# Altium schematic sheet I2C Expander 3.SchDoc("I2C Expander 3")
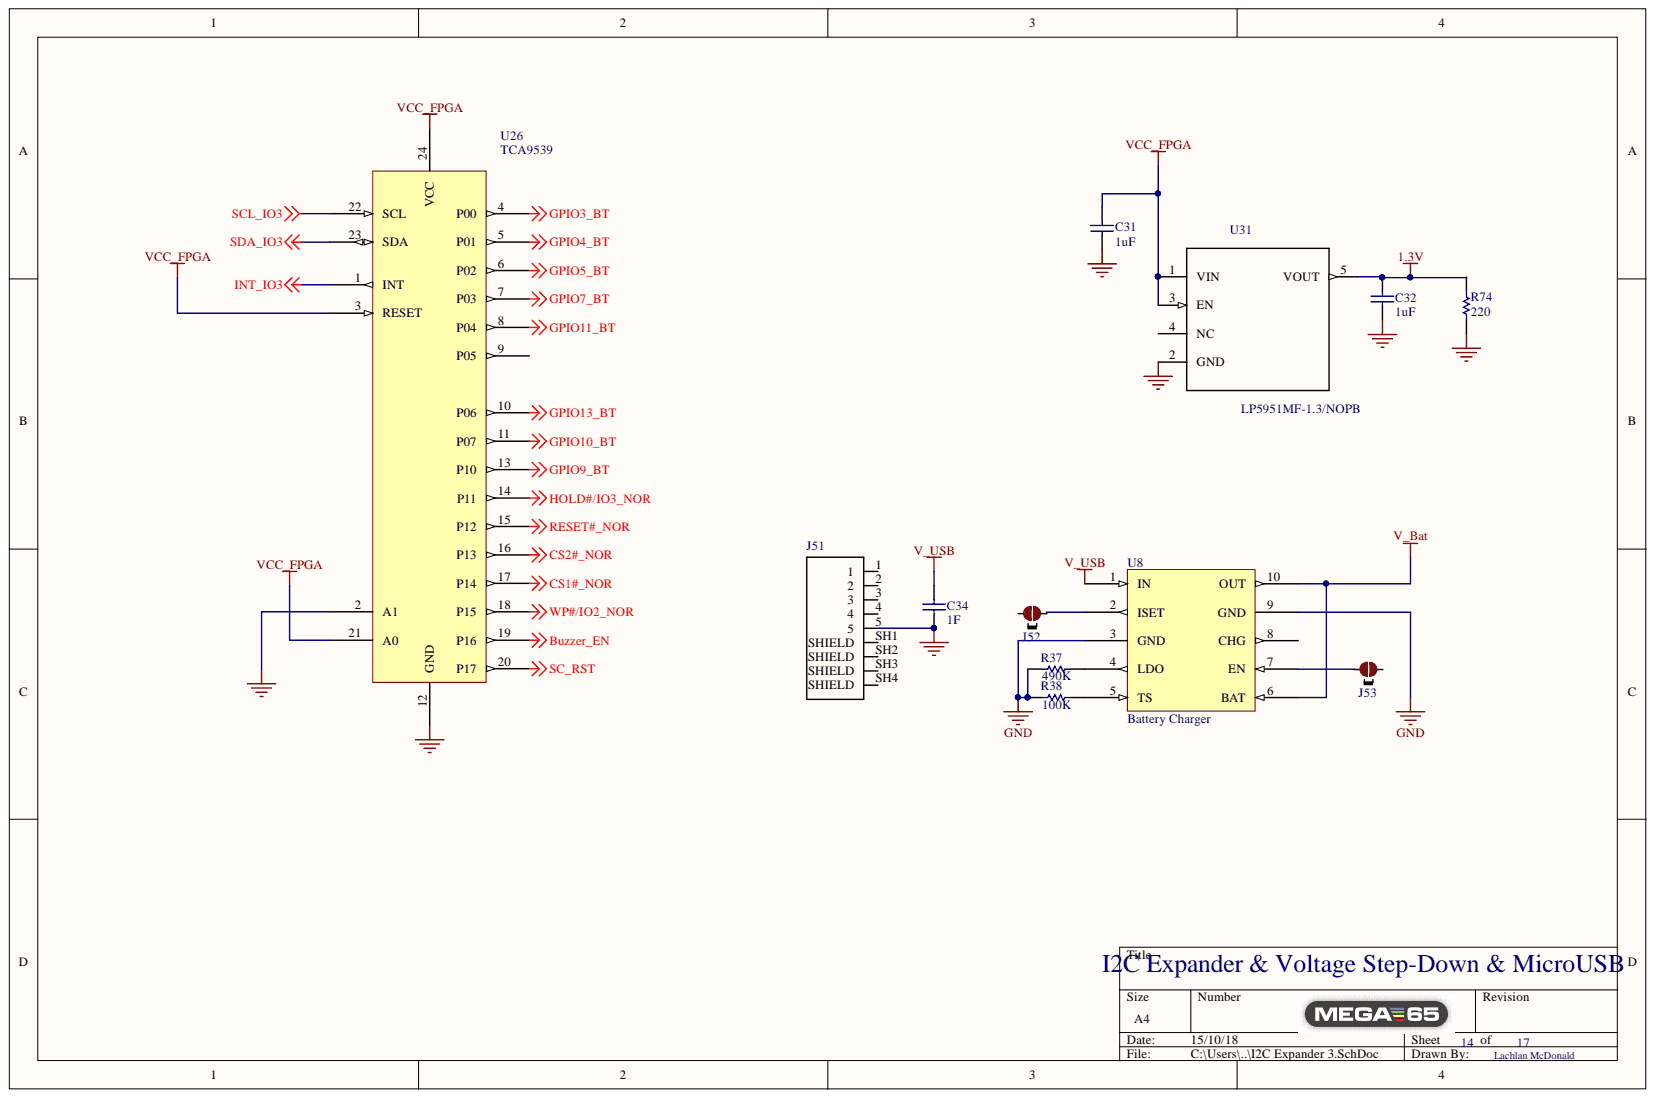
[diagram p0: I2C Expander 3.SchDoc]

## Parts
C31  1uF  [CAPC0805(2012)100_N]
C32  1uF  [CAPC0805(2012)100_N]
C34  1F  [CAPC0805(2012)100_N]
J51  2040343-2  [TE_2040343-2_2040343-2(Primary)]  (value not drawn)
J52  2 way net solder junction - Normally Connected  [2waySolder_NC]  (value not drawn)  (no BOM)
J53  2 way net solder junction - Normally Connected  [2waySolder_NC]  (value not drawn)  (no BOM)
R37  490K  [RESC0805(2012)_M]
R38  100K  [RESC0805(2012)_M]
R74  220  [RESC0805(2012)_M]
U8  Battery Charger  [SON80P400X400X80-10N]
U26  TCA9539  [PW24]
U31  LP5951MF-1.3/NOPB  [SOT95P285X120-5N]

## Nets
1.3V: C32.2 R74.1 U31.5(VOUT)
Buzzer_EN: U26.19(P16)
CS1#_NOR: U26.17(P14)
CS2#_NOR: U26.16(P13)
GND: C31.1 C32.1 C34.1 J51.5 R37.1 R38.1 R74.2 U8.3(GND) U8.9(GND) U26.2(A1) U26.12(GND) U31.2(GND)
GPIO3_BT: U26.4(P00)
GPIO4_BT: U26.5(P01)
GPIO5_BT: U26.6(P02)
GPIO7_BT: U26.7(P03)
GPIO9_BT: U26.13(P10)
GPIO10_BT: U26.11(P07)
GPIO11_BT: U26.8(P04)
GPIO13_BT: U26.10(P06)
HOLD#/IO3_NOR: U26.14(P11)
INT_IO3: U26.1(INT)
RESET#_NOR: U26.15(P12)
SC_RST: U26.20(P17)
SCL_IO3: U26.22(SCL)
SDA_IO3: U26.23(SDA)
V_Bat: U8.6(BAT) U8.10(OUT)
V_USB: C34.2 U8.1(IN)
VCC_FPGA: C31.2 U26.3(RESET) U26.21(A0) U26.24(VCC) U31.1(VIN) U31.3(EN)
WP#/IO2_NOR: U26.18(P15)
NetJ52_2: J52.2 U8.2(ISET)
NetJ53_1: J53.1 U8.7(EN)
NetR37_2: R37.2 U8.4(LDO)
NetR38_2: R38.2 U8.5(TS)

Not connected: J51 1 2 3 4 SH1(SHIELD) SH2(SHIELD) SH3(SHIELD) SH4(SHIELD); J52 1; J53 2; U8 8(CHG); U26 9(P05); U31 4(NC)

## Library data of the parts
C31 C32 C34 = CMP-1036-01531-1: CAP 39pF 100V ±2% 0805 (2012 Metric) Thickness 1mm SMD; Tolerance: Â±2%; Case-Metric: 2012; Case-EIA: 0805; Value: 39pF; Technology: SMT; Rated Voltage: 100 V; Max Thickness: 1 mm; Library Name: Altium Content Vault; Component Kind: Standard
J51 = 2040343-2: Micro USB MID MOUNT TYPE ASSY W/WELDING; Product_Type: Connector; Number_of_Positions: 5; MF: TE Connectivity; Centerline_Pitch: .65 mm[.025 in]; SnapEDA_Link: https://www.snapeda.com/parts/2040343-2/TE%20Connectivity%20AMP%20Connectors/view-part/?ref=snap; Price: None; Package: None; MP: 2040343-2; Library Name: 2040343-2.IntLib; Component Kind: Standard; Availability: Unavailable
J52 J53 = 2waySolderNC: Library Name: NetTieLib.IntLib; Description: 2 way net solder junction - Normally Connected; ComponentLink1URL: http://www.edatools.cz/addons; ComponentLink1Description: Source
R37 R38 R74 = CMP-1013-00623-1: 10K 0.125W 1% 0805 (2012 Metric)  SMD; Value: 10000.00 Ohm; Case-Metric: 2012; Case-EIA: 0805; Tolerance: 1 %; Technology: SMT; Power: 0.125 W; Library Name: Altium Content Vault; Component Kind: Standard
U8 = Battery Charger: bq25071; Library Name: Schlib1.SchLib; Component Kind: Standard
U31 = LP5951MF-1.3/NOPB: Micropower 150mA Low-Dropout _LDO_ CMOS Voltage Regulator 5-SOT-23 -40 to 125; MF: Texas Instruments; SnapEDA_Link: https://www.snapeda.com/parts/LP5951MF-1.3/NOPB/Texas%20Instruments/view-part/?ref=snap; Price: None; Package: None; MP: LP5951MF-1.3/NOPB; Library Name: LP5951MF-1.3_NOPB.IntLib; Component Kind: Standard; Availability: Unavailable
U26 = TCA9539: Library Name: Schlib1.SchLib; Component Kind: Standard
